# Altium schematic sheet [13] - POWER SUPPLY.SchDoc
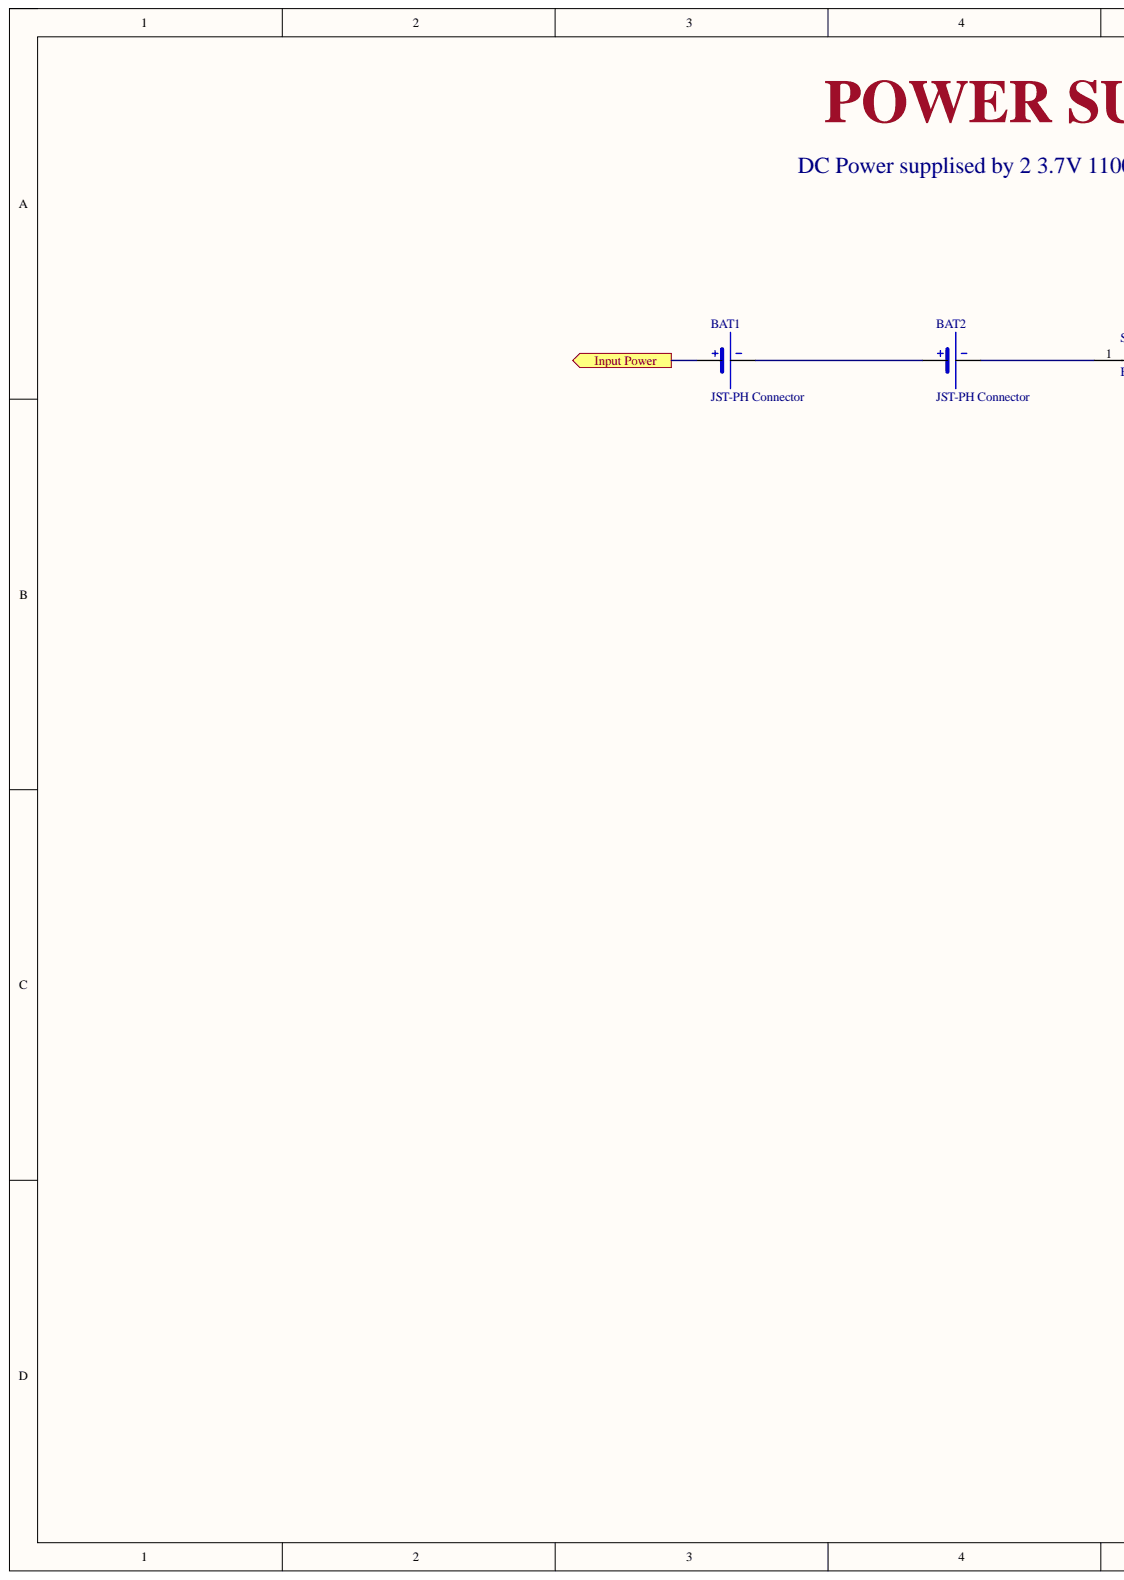
[diagram p0: [13] - POWER SUPPLY.SchDoc, left]
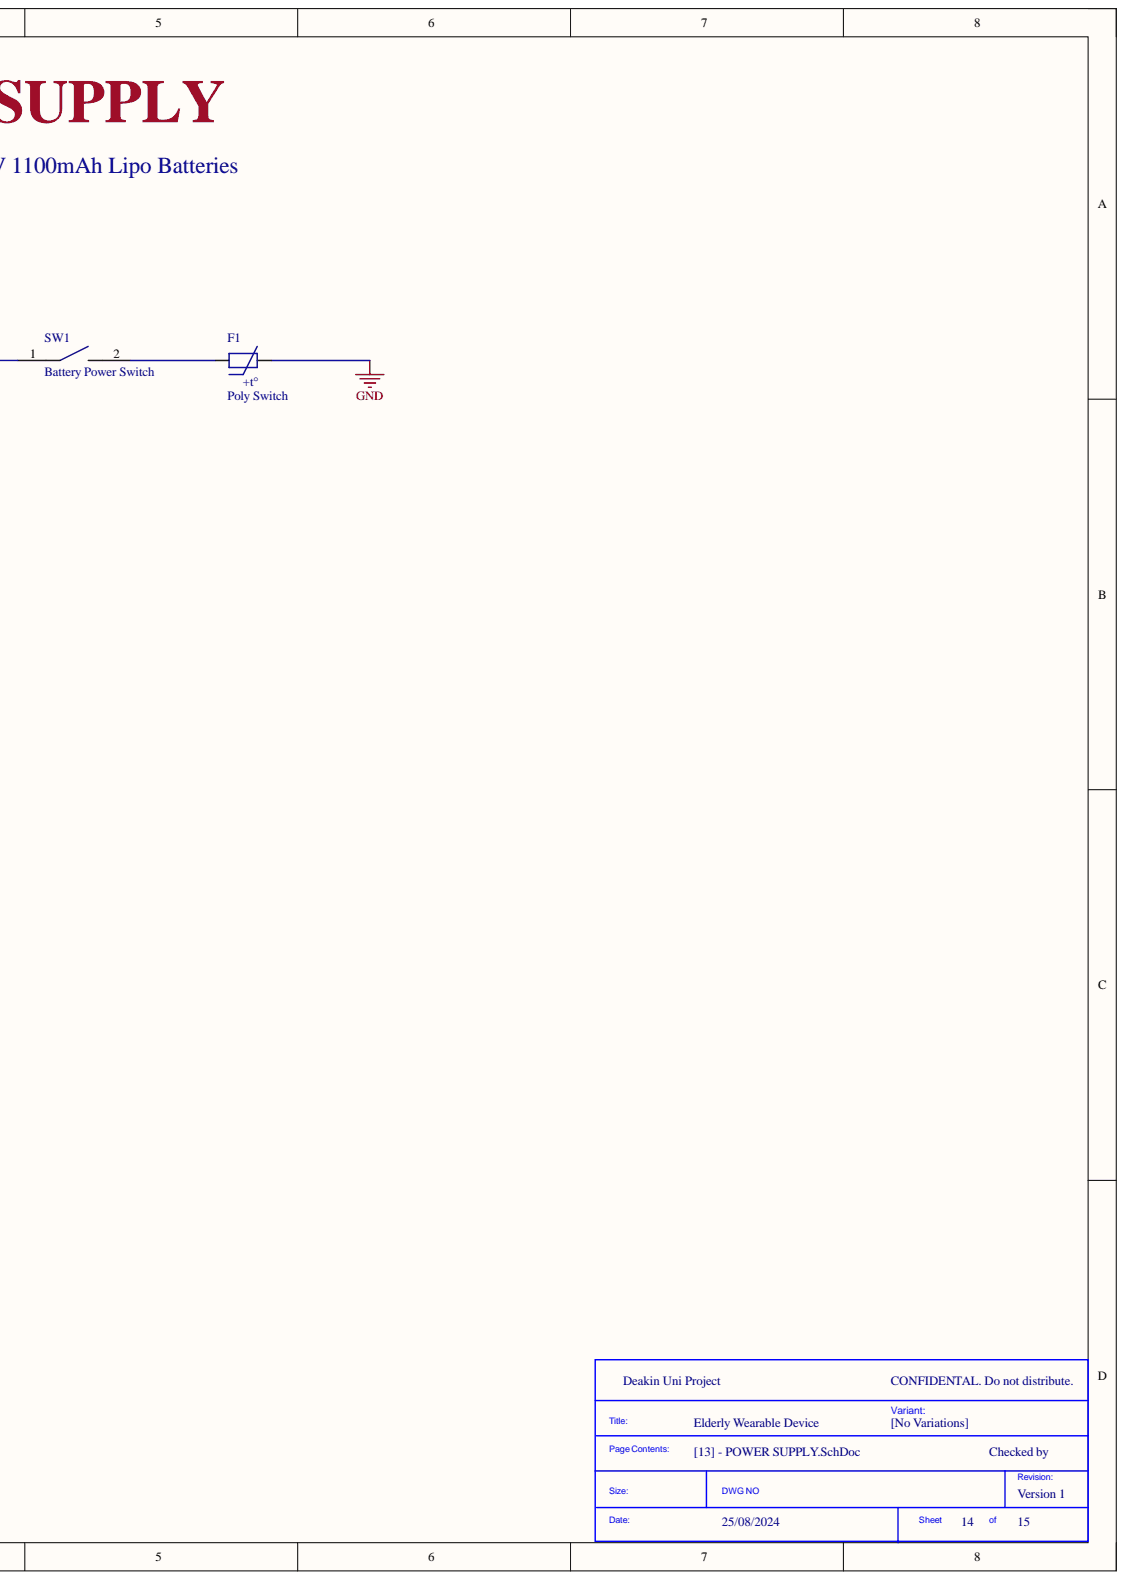
[diagram p1: [13] - POWER SUPPLY.SchDoc, right]

## Parts
BAT1  JST-PH Connector  Package: JST-PH  [JST-PH_Connector]
BAT2  JST-PH Connector  Package: JST-PH  [JST-PH_Connector]
F1  Poly Switch  [Poly_Switch]
SW1  Battery Power Switch  [Battery Power Switch]

## Ports
Input Power

## Nets
GND: F1.2
Input Power: BAT1.1 ; port Input Power
NetBAT1_2: BAT1.2 BAT2.1
NetBAT2_2: BAT2.2 SW1.1
NetF1_1: F1.1 SW1.2

## Library data of the parts
BAT1 BAT2 = JST-PH Connector: Battery 3.7V 1100mAh JST-PH Connector; Manufacturer: JST Sales America Inc.; Manufacturer Part Number: S2B-PH-K-S; ALL GOOD: OK_DONE; Component Kind: Standard; Supplier: Digi-Key; Supplier Part Number: 455-1719-ND; Library Name: Elderly_Wearable_Device.SCHLIB
F1 = Poly Switch: Manufacturer: Bourns Inc.; Manufacturer Part Number: MF-NSHT016KX-2; ALL GOOD: OK_DONE; Component Kind: Standard; Description: Poly Switch; Package: 1206, Concave; Supplier: Digi-Key; Supplier Part Number: MF-NSHT016KX-2CT-ND; Library Name: Elderly_Wearable_Device.SCHLIB
SW1 = Battery Power Switch: Manufacturer: E-Switch; Manufacturer Part Number: 500ASSP1M6QE; ALL GOOD: OK_DONE; Component Kind: Standard; Description: Battery Power Switch; Package: NA; Supplier: Digi-Key; Supplier Part Number: EG2483-ND; Library Name: Elderly_Wearable_Device.SCHLIB
